# Altium schematic sheet SMPSProject.SchDoc(SMPSProject)
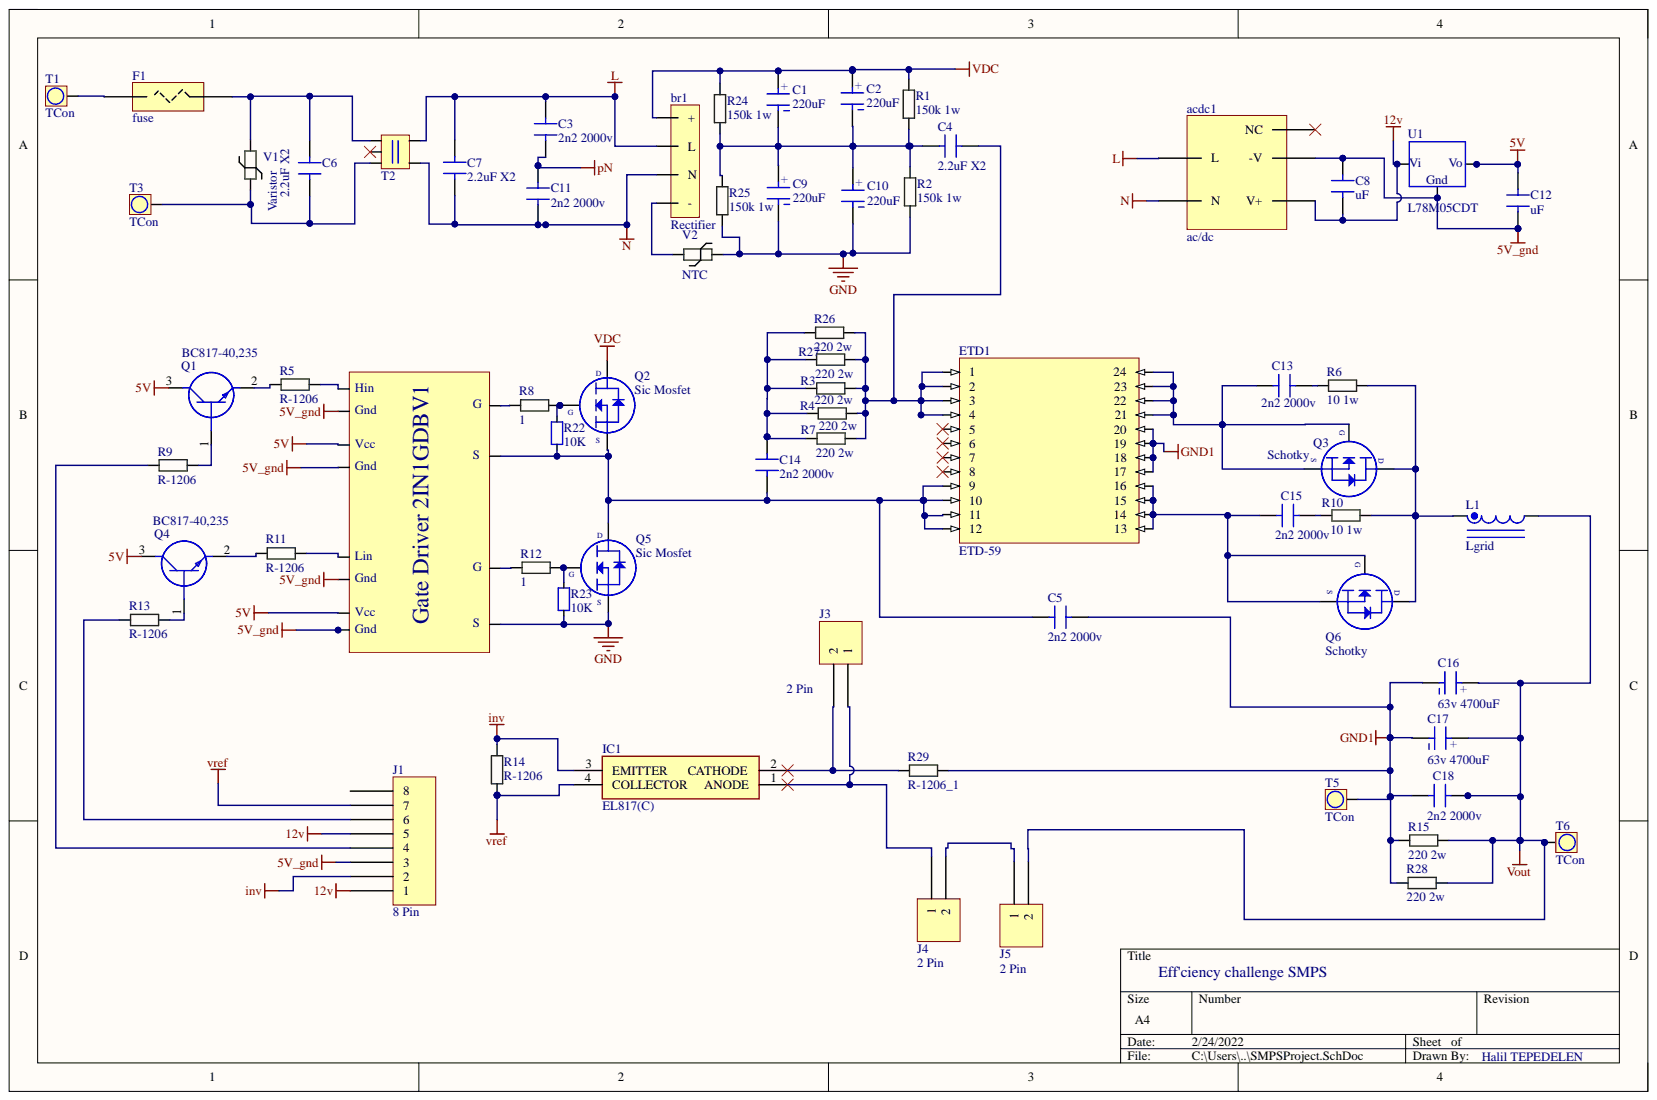
[diagram p0: SMPSProject.SchDoc]

## Parts
acdc1  ac/dc  [ac/dc]
br1  Rectifier  [Rectifier]
C1  220uF  [Koshin]
C2  220uF  [Koshin]
C3  2n2 2000v  [2n2 2000v]
C4  2.2uF X2  [CAP.POL 2.2UF  x2]
C5  2n2 2000v  [2n2 2000v]
C6  2.2uF X2  [CAP.POL 2.2UF  x2]
C7  2.2uF X2  [CAP.POL 2.2UF  x2]
C8  uF  [C-1206]
C9  220uF  [Koshin]
C10  220uF  [Koshin]
C11  2n2 2000v  [2n2 2000v]
C12  uF  [C-1206]
C13  2n2 2000v  [2n2 2000v]
C14  2n2 2000v  [2n2 2000v]
C15  2n2 2000v  [2n2 2000v]
C16  63v 4700uF  [Koshin 63v 4700 cap]
C17  63v 4700uF  [Koshin 63v 4700 cap]
C18  2n2 2000v  [2n2 2000v]
ETD1  ETD-59  [ETD-59]
F1  fuse  [fuse holder]
G1  GateDriver Board  [Gate Driver]  (value not drawn)
IC1  EL817(C)  [DIP762W60P254L458H480Q4N]
J1  8 Pin  [8 pin connector]
J3  2 Pin  [2pin]
J4  2 Pin  [2pin]
J5  2 Pin  [2pin]
L1  Lgrid  [Lgrid]
Q1  BC817-40,235  [SOT95P230X110-3N]
Q2  Sic Mosfet  [IFX-PG-TO247-3-41]
Q3  Schotky  [IFX-PG-TO247-3-41]
Q4  BC817-40,235  [SOT95P230X110-3N]
Q5  Sic Mosfet  [IFX-PG-TO247-3-41]
Q6  Schotky  [IFX-PG-TO247-3-41]
R1  150k 1w  [R-2512]
R2  150k 1w  [R-2512]
R3  220 2w  [R-2512]
R4  220 2w  [R-2512]
R5  R-1206  [R-1206]
R6  10 1w  [R-2512]
R7  220 2w  [R-2512]
R8  1  [R-2512W]
R9  R-1206  [R-1206]
R10  10 1w  [R-2512]
R11  R-1206  [R-1206]
R12  1  [R-2512W]
R13  R-1206  [R-1206]
R14  R-1206  [R-1206]
R15  220 2w  [R-2512]
R22  10K  [R-0805R]
R23  10K  [R-0805R]
R24  150k 1w  [R-2512]
R25  150k 1w  [R-2512]
R26  220 2w  [R-2512]
R27  220 2w  [R-2512]
R28  220 2w  [R-2512]
R29  R-1206_1  [R-1206]
T1  TCon  [tCon]
T2  Transformer  [Transformer]  (value not drawn)
T3  TCon  [tCon]
T5  TCon  [tCon]
T6  TCon  [tCon]
U1  L78M05CDT  [TO-252]
V1  Varistor  [varistor]
V2  NTC  [varistor]

## Nets
5V: C12.2 G1.3(Vcc) G1.7(Vcc) Q1.3 Q4.3 U1.2
5V_gnd: acdc1.4(-V) C8.2 C12.1 G1.2(Gnd) G1.4(Gnd) G1.6(Gnd) G1.8(Gnd) J1.3 U1.3
12v: acdc1.5(V+) C8.1 J1.1 J1.5 U1.1
GND: C9.1 C10.1 G1.12(S) Q5.3 R2.1 R23.1 R25.1 V2.1
GND1: C5.1 C16.1 C17.1 C18.2 ETD1.17 ETD1.18 ETD1.19 ETD1.20 R15.2 R28.2 R29.2 T5.1
inv: IC1.3(EMITTER) J1.2 R14.1
L: acdc1.1(L) br1.2(L) C3.2 C7.2 T2.2
N: acdc1.2(N) br1.3(N) C7.1 C11.1 T2.1
pN: C3.1 C11.2
VDC: br1.1(+) C1.2 C2.2 Q2.2 R1.2 R24.2
Vout: C16.2 C17.2 C18.1 J5.2 L1.2 R15.1 R28.1 T6.1
vref: IC1.4(COLLECTOR) J1.7 R14.2
Netbr1_4: br1.4(-) V2.2
NetC1_1: C1.1 C2.1 C4.2 C9.2 C10.2 R1.1 R2.2 R24.1 R25.2
NetC4_1: C4.1 ETD1.1 ETD1.2 ETD1.3 ETD1.4 R3.1 R4.1 R7.1 R26.1 R27.1
NetC5_2: C5.2 C14.1 ETD1.9 ETD1.10 ETD1.11 ETD1.12 G1.10(S) Q2.3 Q5.2 R22.1
NetC6_1: C6.1 T2.4 T3.1 V1.1
NetC6_2: C6.2 F1.1 T2.3 V1.2
NetC13_1: C13.1 R6.1
NetC13_2: C13.2 ETD1.21 ETD1.22 ETD1.23 ETD1.24 Q3.1 Q3.3
NetC14_2: C14.2 R3.2 R4.2 R7.2 R26.2 R27.2
NetC15_1: C15.1 R10.1
NetC15_2: C15.2 ETD1.13 ETD1.14 ETD1.15 ETD1.16 Q6.1 Q6.3
NetF1_2: F1.2 T1.1
NetG1_1: G1.1(Hin) R5.2
NetG1_5: G1.5(Lin) R11.2
NetG1_9: G1.9(G) R8.1
NetG1_11: G1.11(G) R12.1
NetIC1_1: IC1.1(ANODE) J3.1 J4.1
NetIC1_2: IC1.2(CATHODE) J3.2 R29.1
NetJ1_4: J1.4 R9.1
NetJ1_6: J1.6 R13.1
NetJ4_2: J4.2 J5.1
NetL1_1: L1.1 Q3.2 Q6.2 R6.2 R10.2
NetQ1_1: Q1.1 R9.2
NetQ1_2: Q1.2 R5.1
NetQ2_1: Q2.1 R8.2 R22.2
NetQ4_1: Q4.1 R13.2
NetQ4_2: Q4.2 R11.1
NetQ5_1: Q5.1 R12.2 R23.2

Not connected: acdc1 3(NC); ETD1 5 6 7 8; J1 8; T2 5

## Library data of the parts
C1 C2 C9 C10 = CAP.EL.220uF 500V: Component Kind: Standard; ComponentLink1Description: https://www.ozdisan.com/pasif-komponentler/kondansatorler/aluminyum-kondansatorler/K055002210PM0E040; ComponentLink1URL: https://www.ozdisan.com/pasif-komponentler/kondansatorler/aluminyum-kondansatorler/K055002210PM0E040; Library Name: SMPSProject.SchLib
C8 C12 = C-1206: Component Kind: Standard; Library Name: SMPSProject.SchLib
C16 C17 = Aluminum Electrolytic Capacitors: PKL5-063V472MM450 Aluminum Electrolytic Capacitors - Snap In 63volts 4700uF 20%; Manufacturer: Nichicon; Manufacturer Part Number: LKS1J472MESZ; Capacitance: 4.7mF; Life (Hours): 1000Hour; Ripple Current: 3A; Case/Package: Radial; Component Kind: Standard; ComponentLink2Description: https://www.ozdisan.com/pasif-komponentler/kondansatorler/aluminyum-kondansatorler/PKL5-063V472MM450; ComponentLink2URL: https://www.ozdisan.com/pasif-komponentler/kondansatorler/aluminyum-kondansatorler/PKL5-063V472MM450; Diameter: 22mm; Height - Seated (Max): 1.772inch; Lead Pitch: 0.394inch; Length: 45mm; Max Operating Temperature: 85°C; Min Operating Temperature: -40°C; Mount: Through Hole; Package Quantity: 250; Packaging: Bulk; RoHS: Yes; Supplier 1: Mouser; Supplier Part Number 1: 647-LKS1J472MESZ; Termination: Snap in; Tolerance: 20%; Voltage Rating: 63V; Voltage Rating (DC): 63V; Library Name: SMPSProject.SchLib
IC1 = EL817(C): Integrated Circuit; Manufacturer_Name: Everlight; Component Kind: Standard; Datasheet Link: https://componentsearchengine.com/Datasheets/1/EL817(C).pdf; Height: 4.8mm; Manufacturer_Part_Number: EL817(C); Mouser Part Number: 638-EL817C; Mouser Price/Stock: https://www.mouser.com/Search/Refine.aspx?Keyword=638-EL817C; Library Name: SMPSProject.SchLib
Q1 Q4 = BC817-40,235: Transistor BJT NPN; Manufacturer_Name: Nexperia; Component Kind: Standard; Datasheet Link: http://assets.nexperia.com/documents/data-sheet/BC817_BC817W_BC337.pdf; Height: 1.1mm; Manufacturer_Part_Number: BC817-40,235; Mouser Part Number: 771-BC817-40235; Mouser Price/Stock: https://www.mouser.com/Search/Refine.aspx?Keyword=771-BC817-40235; RS Part Number: 1707957; RS Price/Stock: http://uk.rs-online.com/web/p/products/1707957; Library Name: SMPSProject.SchLib
Q2 Q3 Q5 Q6 = Sic Mosfet To247: Component Kind: Standard; Description: SiC Mosfet To247; Library Name: SMPSProject.SchLib
R1-R3 R7 R15 R24-R28 = R-2512: 10 1w; Component Kind: Standard; Library Name: SMPSProject.SchLib
R4 R6 R10 = R-2512: Component Kind: Standard; Library Name: SMPSProject.SchLib
  R4: Description: 220 2w
  R6 R10: Description: 10 1w
R5 R9 R11 R13 R14 = R-1206: Res1206; Component Kind: Standard; Library Name: SMPSProject.SchLib
R8 R12 = 0207 melf: Component Kind: Standard; ComponentLink1Description: https://www.ozdisan.com/pasif-komponentler/direncler/smt-smd-direncler/M271UFG100KT20; ComponentLink1URL: https://www.ozdisan.com/pasif-komponentler/direncler/smt-smd-direncler/M271UFG100KT20; Library Name: SMPSProject.SchLib
R22 R23 = 10K 0805: Component Kind: Standard; Library Name: SMPSProject.SchLib
R29 = R-1206_1: Res1206; Component Kind: Standard; Library Name: SMPSProject.SchLib
T1 T3 T5 T6 = Terminal Connector: Component Kind: Standard; ComponentLink1Description: https://www.ozdisan.com/konnektor-baglanti-elemanlari/terminal-konnektorler/vida-tipi-konnektorler/K36-00AH; ComponentLink1URL: https://www.ozdisan.com/konnektor-baglanti-elemanlari/terminal-konnektorler/vida-tipi-konnektorler/K36-00AH; Library Name: SMPSProject.SchLib
U1 = L78M15CDT_1: Manufacturer: STMicroelectronics; Dropout Voltage: 2V; Max Input Voltage: 35V; Max Output Current: 500mA; Number of Outputs: 1; Output Type: Fixed; Output Voltage: 15V; Polarity: Positive; Quiescent Current: 6mA; Case/Package: DPAK; Component Kind: Standard; ComponentLink1Description: link; ComponentLink1URL: https://karakoyelektronik.com/regulator/ua78m15-21734; Height: 2.4mm; Length: 6.6mm; Manufacturer Part Number: L78M15CDT; Max Operating Temperature: 150°C; Min Input Voltage: 35V; Min Operating Temperature: 0°C; Mount: Surface Mount; Number of Pins: 3; Output Current: 500mA; Package Quantity: 75; Packaging: Rail/Tube; Radiation Hardening: No; RoHS: Yes; Supplier 1: Avnet; Supplier Part Number 1: L78M15CDT; Width: 6.2mm; Library Name: SMPSProject.SchLib
V2 = Varistor: Component Kind: Standard; ComponentLink1Description: Link; ComponentLink1URL: https://ozdisan.com/power-semiconductors/circuit-protection-components/varistors/TVR10391KSY; Library Name: SMPSProject.SchLib
acdc1 = ac/dc: Component Kind: Standard; Library Name: SMPSProject.SchLib
br1 = Rectifier: Component Kind: Standard; Library Name: SMPSProject.SchLib
C3 C5 C11 C13-C15 C18 = 2n2 2000v: Component Kind: Standard; Library Name: SMPSProject.SchLib
C4 C6 C7 = 2.2uF X2: Component Kind: Standard; Library Name: SMPSProject.SchLib
ETD1 = ETD-59: Component Kind: Standard; Library Name: E.R.U_V1.IntLib
F1 = fuse: Component Kind: Standard; Library Name: SMPSProject.SchLib
G1 = GateDriver Board: Component Kind: Standard; Library Name: SMPSProject.SchLib
J1 = 8 Pin: Component Kind: Standard; Library Name: SMPSProject.SchLib
J3-J5 = 2 Pin: Component Kind: Standard; Library Name: SMPSProject.SchLib
L1 = Lgrid: Component Kind: Standard; Library Name: SMPSProject.SchLib
T2 = Transformer: Component Kind: Standard; Library Name: SMPSProject.SchLib
V1 = Varistor: Component Kind: Standard; ComponentLink1Description: Link; ComponentLink1URL: https://ozdisan.com/power-semiconductors/circuit-protection-components/varistors/TVR10391KSY; Library Name: SMPSProject.SchLib
